# Altium schematic sheet 1166V30-1.SchDoc
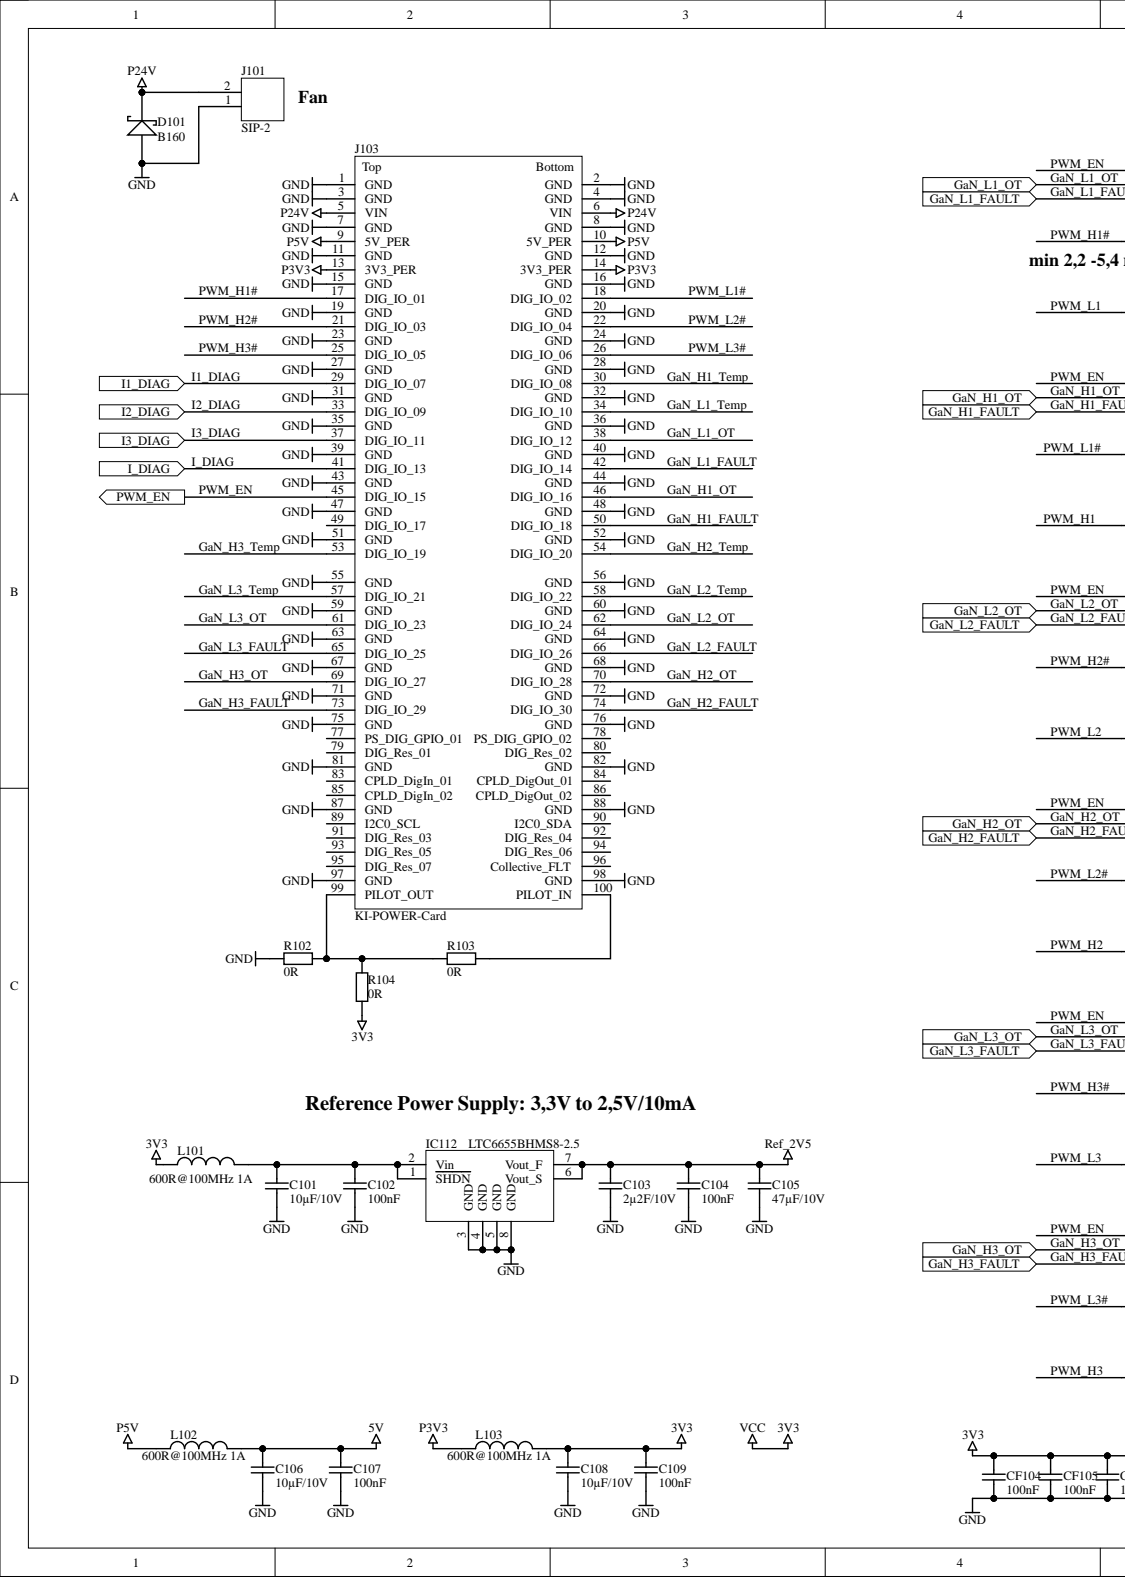
[diagram p0: 1166V30-1.SchDoc, left]
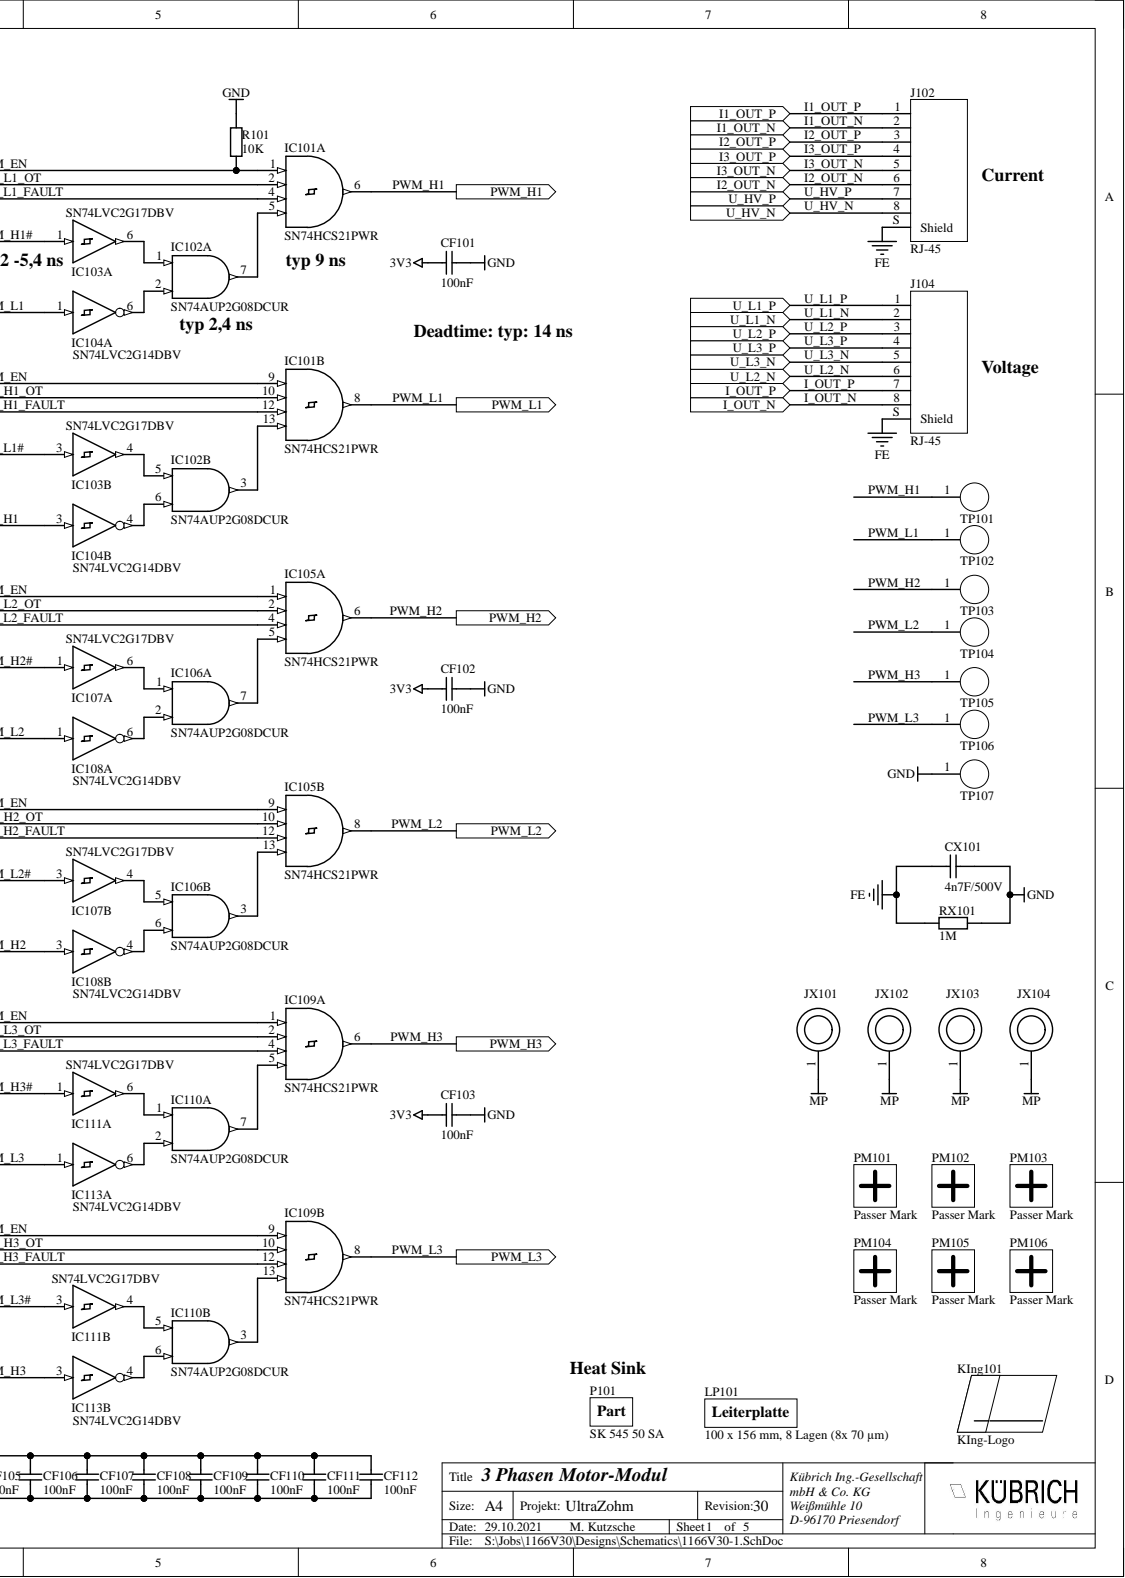
[diagram p1: 1166V30-1.SchDoc, right]

## Parts
C101  10µF/10V  [0805-Cap]
C102  100nF  [0603-Cap]
C103  2µ2F/10V  [0805-Cap]
C104  100nF  [0603-Cap]
C105  47µF/10V  [1210-Cap]
C106  10µF/10V  [0603-Cap]
C107  100nF  [0603-Cap]
C108  10µF/10V  [0603-Cap]
C109  100nF  [0603-Cap]
CF101  100nF  [0603-Cap]
CF102  100nF  [0603-Cap]
CF103  100nF  [0603-Cap]
CF104  100nF  [0603-Cap]
CF105  100nF  [0603-Cap]
CF106  100nF  [0603-Cap]
CF107  100nF  [0603-Cap]
CF108  100nF  [0603-Cap]
CF109  100nF  [0603-Cap]
CF110  100nF  [0603-Cap]
CF111  100nF  [0603-Cap]
CF112  100nF  [0603-Cap]
CX101  4n7F/500V  [1206-Cap]
D101  B160  [SMA]
IC101  SN74HCS21PWR  [TSSOP-14]  (parts A,B)
IC102  SN74AUP2G08DCUR  [VSSOP-8]  (parts A,B)
IC103  SN74LVC2G17DBV  [SOT-23-6]  (parts A,B)
IC104  SN74LVC2G14DBV  [SOT-23-6]  (parts A,B)
IC105  SN74HCS21PWR  [TSSOP-14]  (parts A,B)
IC106  SN74AUP2G08DCUR  [VSSOP-8]  (parts A,B)
IC107  SN74LVC2G17DBV  [SOT-23-6]  (parts A,B)
IC108  SN74LVC2G14DBV  [SOT-23-6]  (parts A,B)
IC109  SN74HCS21PWR  [TSSOP-14]  (parts A,B)
IC110  SN74AUP2G08DCUR  [VSSOP-8]  (parts A,B)
IC111  SN74LVC2G17DBV  [SOT-23-6]  (parts A,B)
IC112  LTC6655BHMS8-2.5  [MSOP-8 (RM-8)]
IC113  SN74LVC2G14DBV  [SOT-23-6]  (parts A,B)
J101  SIP-2  [SIP-2]
J102  RJ-45  [WE-634008149821]
J103  KI-POWER-Card  [KI-POWER-CARD]
J104  RJ-45  [WE-634008149821]
JX101  Drill PAD  [PAD-6.0/3.2]  (value not drawn)  (no BOM)
JX102  Drill PAD  [PAD-6.0/3.2]  (value not drawn)  (no BOM)
JX103  Drill PAD  [PAD-6.0/3.2]  (value not drawn)  (no BOM)
JX104  Drill PAD  [PAD-6.0/3.2]  (value not drawn)  (no BOM)
KIng101  KIng-Logo  [King_Logo 900x300mil short]  (no BOM)
L101  600R@100MHz 1A  [0603-Ind]
L102  600R@100MHz 1A  [0603-Ind]
L103  600R@100MHz 1A  [0603-Ind]
LP101  100 x 156 mm, 8 Lagen (8x 70 µm)  [Größe 100 x 156 mm]
P101  SK 545 50 SA  [Part]
PM101  Passer Mark  [PASSER-MARK Rund 1,2mm]
PM102  Passer Mark  [PASSER-MARK Rund 1,2mm]
PM103  Passer Mark  [PASSER-MARK Rund 1,2mm]
PM104  Passer Mark  [PASSER-MARK Rund 1,2mm]
PM105  Passer Mark  [PASSER-MARK Rund 1,2mm]
PM106  Passer Mark  [PASSER-MARK Rund 1,2mm]
R101  10K  [0603-Res]
R102  0R  [0603-Res]
R103  0R  [0603-Res]
R104  0R  [0603-Res]
RX101  1M  [1206-Res]
TP101  Test-Point  [PAD-1.0/1.8]  (value not drawn)  (no BOM)
TP102  Test-Point  [PAD-1.0/1.8]  (value not drawn)  (no BOM)
TP103  Test-Point  [PAD-1.0/1.8]  (value not drawn)  (no BOM)
TP104  Test-Point  [PAD-1.0/1.8]  (value not drawn)  (no BOM)
TP105  Test-Point  [PAD-1.0/1.8]  (value not drawn)  (no BOM)
TP106  Test-Point  [PAD-1.0/1.8]  (value not drawn)  (no BOM)
TP107  Test-Point  [PAD-1.0/1.8]  (value not drawn)  (no BOM)

## Ports
GaN_H1_FAULT, GaN_H1_OT, GaN_H2_FAULT, GaN_H2_OT, GaN_H3_FAULT, GaN_H3_OT, GaN_L1_FAULT, GaN_L1_OT, GaN_L2_FAULT, GaN_L2_OT, GaN_L3_FAULT, GaN_L3_OT, I1_DIAG, I1_OUT_N, I1_OUT_P, I2_DIAG, I2_OUT_N, I2_OUT_P, I3_DIAG, I3_OUT_N, I3_OUT_P, I_DIAG, I_OUT_N, I_OUT_P, PWM_EN, PWM_H1, PWM_H2, PWM_H3, PWM_L1, PWM_L2, PWM_L3, U_HV_N, U_HV_P, U_L1_N, U_L1_P, U_L2_N, U_L2_P, U_L3_N, U_L3_P

## Nets
3V3: C108.2 C109.2 CF101.2 CF102.2 CF103.2 CF104.1 CF105.1 CF106.1 CF107.1 CF108.1 CF109.1 CF110.1 CF111.1 CF112.1 IC101.14 IC102.8 IC103.5 IC104.5 IC105.14 IC106.8 IC107.5 IC108.5 IC109.14 IC110.8 IC111.5 IC113.5 L101.1 L103.2 R104.1
5V: C106.2 C107.2 L102.2
FE: CX101.1 J102.S(Shield) J104.S(Shield) RX101.1
GND: C101.1 C102.1 C103.1 C104.1 C105.1 C106.1 C107.1 C108.1 C109.1 CF101.1 CF102.1 CF103.1 CF104.2 CF105.2 CF106.2 CF107.2 CF108.2 CF109.2 CF110.2 CF111.2 CF112.2 CX101.2 D101.1 IC101.7 IC102.4 IC103.2 IC104.2 IC105.7 IC106.4 IC107.2 IC108.2 IC109.7 IC110.4 IC111.2 IC112.3(GND) IC112.4(GND) IC112.5(GND) IC112.8(GND) IC113.2 J101.1 J103.1(GND) J103.2(GND) J103.3(GND) J103.4(GND) J103.7(GND) J103.8(GND) J103.11(GND) J103.12(GND) J103.15(GND) J103.16(GND) J103.19(GND) J103.20(GND) J103.23(GND) J103.24(GND) J103.27(GND) J103.28(GND) J103.31(GND) J103.32(GND) J103.35(GND) J103.36(GND) J103.39(GND) J103.40(GND) J103.43(GND) J103.44(GND) J103.47(GND) J103.48(GND) J103.51(GND) J103.52(GND) J103.55(GND) J103.56(GND) J103.59(GND) J103.60(GND) J103.63(GND) J103.64(GND) J103.67(GND) J103.68(GND) J103.71(GND) J103.72(GND) J103.75(GND) J103.76(GND) J103.81(GND) J103.82(GND) J103.87(GND) J103.88(GND) J103.97(GND) J103.98(GND) R101.2 R102.1 RX101.2 TP107.1
MP: JX101.1 JX102.1 JX103.1 JX104.1
P3V3: J103.13(3V3_PER) J103.14(3V3_PER) L103.1
P5V: J103.9(5V_PER) J103.10(5V_PER) L102.1
P24V: D101.2 J101.2 J103.5(VIN) J103.6(VIN)
Ref_2V5: C103.2 C104.2 C105.2 IC112.6(Vout_S) IC112.7(Vout_F)
GaN_H1_FAULT: IC101.12 J103.50(DIG_IO_18) ; port GaN_H1_FAULT
GaN_H1_OT: IC101.10 J103.46(DIG_IO_16) ; port GaN_H1_OT
GaN_H1_Temp: J103.30(DIG_IO_08)
GaN_H2_FAULT: IC105.12 J103.74(DIG_IO_30) ; port GaN_H2_FAULT
GaN_H2_OT: IC105.10 J103.70(DIG_IO_28) ; port GaN_H2_OT
GaN_H2_Temp: J103.54(DIG_IO_20)
GaN_H3_FAULT: IC109.12 J103.73(DIG_IO_29) ; port GaN_H3_FAULT
GaN_H3_OT: IC109.10 J103.69(DIG_IO_27) ; port GaN_H3_OT
GaN_H3_Temp: J103.53(DIG_IO_19)
GaN_L1_FAULT: IC101.4 J103.42(DIG_IO_14) ; port GaN_L1_FAULT
GaN_L1_OT: IC101.2 J103.38(DIG_IO_12) ; port GaN_L1_OT
GaN_L1_Temp: J103.34(DIG_IO_10)
GaN_L2_FAULT: IC105.4 J103.66(DIG_IO_26) ; port GaN_L2_FAULT
GaN_L2_OT: IC105.2 J103.62(DIG_IO_24) ; port GaN_L2_OT
GaN_L2_Temp: J103.58(DIG_IO_22)
GaN_L3_FAULT: IC109.4 J103.65(DIG_IO_25) ; port GaN_L3_FAULT
GaN_L3_OT: IC109.2 J103.61(DIG_IO_23) ; port GaN_L3_OT
GaN_L3_Temp: J103.57(DIG_IO_21)
I1_DIAG: J103.29(DIG_IO_07) ; port I1_DIAG
I1_OUT_N: J102.2 ; port I1_OUT_N
I1_OUT_P: J102.1 ; port I1_OUT_P
I2_DIAG: J103.33(DIG_IO_09) ; port I2_DIAG
I2_OUT_N: J102.6 ; port I2_OUT_N
I2_OUT_P: J102.3 ; port I2_OUT_P
I3_DIAG: J103.37(DIG_IO_11) ; port I3_DIAG
I3_OUT_N: J102.5 ; port I3_OUT_N
I3_OUT_P: J102.4 ; port I3_OUT_P
I_DIAG: J103.41(DIG_IO_13) ; port I_DIAG
I_OUT_N: J104.8 ; port I_OUT_N
I_OUT_P: J104.7 ; port I_OUT_P
PWM_EN: IC101.1 IC101.9 IC105.1 IC105.9 IC109.1 IC109.9 J103.45(DIG_IO_15) R101.1 ; port PWM_EN
PWM_H1: IC101.6 IC104.3 TP101.1 ; port PWM_H1
PWM_H1#: IC103.1 J103.17(DIG_IO_01)
PWM_H2: IC105.6 IC108.3 TP103.1 ; port PWM_H2
PWM_H2#: IC107.1 J103.21(DIG_IO_03)
PWM_H3: IC109.6 IC113.3 TP105.1 ; port PWM_H3
PWM_H3#: IC111.1 J103.25(DIG_IO_05)
PWM_L1: IC101.8 IC104.1 TP102.1 ; port PWM_L1
PWM_L1#: IC103.3 J103.18(DIG_IO_02)
PWM_L2: IC105.8 IC108.1 TP104.1 ; port PWM_L2
PWM_L2#: IC107.3 J103.22(DIG_IO_04)
PWM_L3: IC109.8 IC113.1 TP106.1 ; port PWM_L3
PWM_L3#: IC111.3 J103.26(DIG_IO_06)
U_HV_N: J102.8 ; port U_HV_N
U_HV_P: J102.7 ; port U_HV_P
U_L1_N: J104.2 ; port U_L1_N
U_L1_P: J104.1 ; port U_L1_P
U_L2_N: J104.6 ; port U_L2_N
U_L2_P: J104.3 ; port U_L2_P
U_L3_N: J104.5 ; port U_L3_N
U_L3_P: J104.4 ; port U_L3_P
NetC101_2: C101.2 C102.2 IC112.1(SHDN) IC112.2(Vin) L101.2
NetIC101_5: IC101.5 IC102.7
NetIC101_13: IC101.13 IC102.3
NetIC102_1: IC102.1 IC103.6
NetIC102_2: IC102.2 IC104.6
NetIC102_5: IC102.5 IC103.4
NetIC102_6: IC102.6 IC104.4
NetIC105_5: IC105.5 IC106.7
NetIC105_13: IC105.13 IC106.3
NetIC106_1: IC106.1 IC107.6
NetIC106_2: IC106.2 IC108.6
NetIC106_5: IC106.5 IC107.4
NetIC106_6: IC106.6 IC108.4
NetIC109_5: IC109.5 IC110.7
NetIC109_13: IC109.13 IC110.3
NetIC110_1: IC110.1 IC111.6
NetIC110_2: IC110.2 IC113.6
NetIC110_5: IC110.5 IC111.4
NetIC110_6: IC110.6 IC113.4
NetJ103_99: J103.99(PILOT_OUT) R102.2 R103.1 R104.2
NetJ103_100: J103.100(PILOT_IN) R103.2

Not connected: J103 49(DIG_IO_17) 77(PS_DIG_GPIO_01) 78(PS_DIG_GPIO_02) 79(DIG_Res_01) 80(DIG_Res_02) 83(CPLD_DigIn_01) 84(CPLD_DigOut_01) 85(CPLD_DigIn_02) 86(CPLD_DigOut_02) 89(I2C0_SCL) 90(I2C0_SDA) 91(DIG_Res_03) 92(DIG_Res_04) 93(DIG_Res_05) 94(DIG_Res_06) 95(DIG_Res_07) 96(Collective_FLT)

## Library data of the parts
C101-C109 CF101-CF112 CX101 = Cap_SMD: Capacitor (SMD); Class I: Pasiv; Class II: Capacitor; Component Kind: Standard; Last edited: 20.11.2006 10:25; Library Name: KIng_RLC.IntLib
  C101 C106 C108: value: 10µF/10V
  C102 C104 C107 C109 CF101-CF112: value: 100nF
  C103: value: 2µ2F/10V
  C105: value: 47µF/10V
  CX101: value: 4n7F/500V
D101 = B160: Schottky Barrier Rectifier Vr=60V If=1A; Manufacturer: General Semiconductor; Best.-Nr.: Farnell 145-6528RL; Class I: Discret; Class II: Schottky Earrier Rectifier Vr=60V If=1A; Package information: Unknown; Component Kind: Standard; Datasheet: Ver. 7 Nov 2000; Package reference: DO-214AC (SMA); Library Name: KIng_Dioden.IntLib
IC101 IC105 IC109 = SN74HCS21PWR: Dual 4-Input AND Gate with Schmitt Trigger Input; Manufacturer: Texas Instrument; Best.-Nr.: DigiKey: 296-SN74HCS21PWRCT-ND; Class I: Logic; Package Information: Unknown; Class II: Gate; Component Kind: Standard; Datasheet: SCES200J; Package Reference: TSSOP-14; Library Name: KIng_Logik.IntLib
IC102 IC106 IC110 = SN74AUP2G08DCUR: (VSSOP) Dual 2-Input Positive AND Gate; Manufacturer: Texas Instrument; Class I: Logic; Package Information: Unknown; Class II: Dual 2-Input Positive AND Gate; Component Kind: Standard; Datasheet: SCES193J, Rev. Sep 2003; Package Reference: VSSOP-8; Library Name: KIng_Logik.IntLib
IC103 IC107 IC111 = SN74LVC2G17D_: Dual Buffer with Schmitt Trigger Input; Manufacturer: Texas Instrument; Best.-Nr.: Farnell 147-0776 (SOT23-6), 128-7569 (SC-70); Class I: Logic; value121: SN74LVC2G17DCK; Class II: Dual Buffer with Schmitt Trigger Input; Component Kind: Standard; Datasheet: SCES381E, Rev. Sep 2003; Last edited: 22.01.2007 11:08; value111: SN74LVC2G17DBV; Library Name: KIng_Logik.IntLib
IC104 IC108 IC113 = SN74LVC2G14D_: Dual Inverter with Schmitt Trigger Input; Manufacturer: Texas Instrument; Class I: Logic; value121: SN74LVC2G14DCK; Class II: Dual Inverter with Schmitt Trigger Input; Component Kind: Standard; Datasheet: SCES200J; Last edited: 22.01.2007 11:08; value111: SN74LVC2G14DBV; Library Name: KIng_Logik.IntLib
IC112 = LTC6655BHMS8-2.5: Voltage References 2,5V, 2ppm/°C, Low Noise 0,25ppm; Manufacturer: Linear Technology; Best.-Nr.: Farnell 229-5508; Class I: Discret; Package information: Unknown; Class II: Voltage References 2,5V, 2ppm/°C, Low Noise 0,25ppm; Component Kind: Standard; Datasheet: Unknown; Package reference: MSOP-8; Library Name: KIng_Reset & Voltage ref.IntLib
J101 = SIP-2: Single In-line Connector 2 pin; Class I: Connectors; Class II: Single In-line Connector 2 pin; Component Kind: Standard; Last edited: 08.12.2006 17:09; Library Name: KIng_Connector.IntLib
J102 J104 = WE-634008149821: SMD CAT3 RJ-45 Connector; Manufacturer: Würth Elektronik; Class I: Connectors; Best.-Nr.: WE-634008149821; Class II: RJ-45 Connector; Component Kind: Standard; Datasheet: Unknown; Package reference: WE-634008149821; Library Name: KIng_Connector.IntLib
J103 = KI-POWER-Card: Einsteckkarte für KI-POWER Projekt (Ultra ZYNQ); Manufacturer: Samtec; Best.-Nr.: Gegenstecker: Samtec HSEC8-150-01-XX-DV-A-BL; Class I: Connectors; Class II: Einsteckkarte für KI-POWER Projekt (Ultra ZYNQ); Component Kind: Standard; Datasheet: 2000/1; Last edited: 07.09.2020 13:28; Library Name: KIng_Miscellaneous.IntLib
JX101-JX104 = Drill PAD: PAD; Library Name: KIng_Utilities.IntLib
KIng101 = KIng-Logo: Kuebrich-Ing. Logo; Library Name: KIng_Utilities.IntLib
L101-L103 = WE-742792651: Ferrite SMD 600R@100MHz 1A (0603); Manufacturer: Wuerth-Elektronik; Class I: Pasive; Best.-Nr.: WE-742792651; Class II: Ferrite SMD 600R@100MHz 1A; Component Kind: Standard; Last edited: 08.12.2006 12:28; Library Name: KIng_RLC.IntLib
LP101 = Leiterplatte: Leiterplatte 100 x 156 mm, 8 Lagen (8x 70 µm); Manufacturer: Generic Manufacturer; Component Kind: Standard; Library Name: KIng_Utilities.IntLib
P101 = Part: Kühlkörper 80x50x10 mm; Manufacturer: Fischer Elektronik; Best.-Nr.: SK 545 50 SA; Component Kind: Standard; Library Name: KIng_Utilities.IntLib
R101-R104 RX101 = Res_SMD: Resistor SMD; Class I: Pasiv; Class II: Resistor; Component Kind: Standard; Last edited: 17.11.2006 11:38; Library Name: KIng_RLC.IntLib
  R101: value: 10K
  R102-R104: value: 0R
  RX101: value: 1M
TP101-TP107 = Test-Point: PAD; Library Name: KIng_Utilities.IntLib
PM101-PM106 = Passer Mark: Component Kind: Standard; Description: Passer Mark; Library Name: KIng_Utilities.IntLib
